# Altium schematic sheet Audio.SchDoc
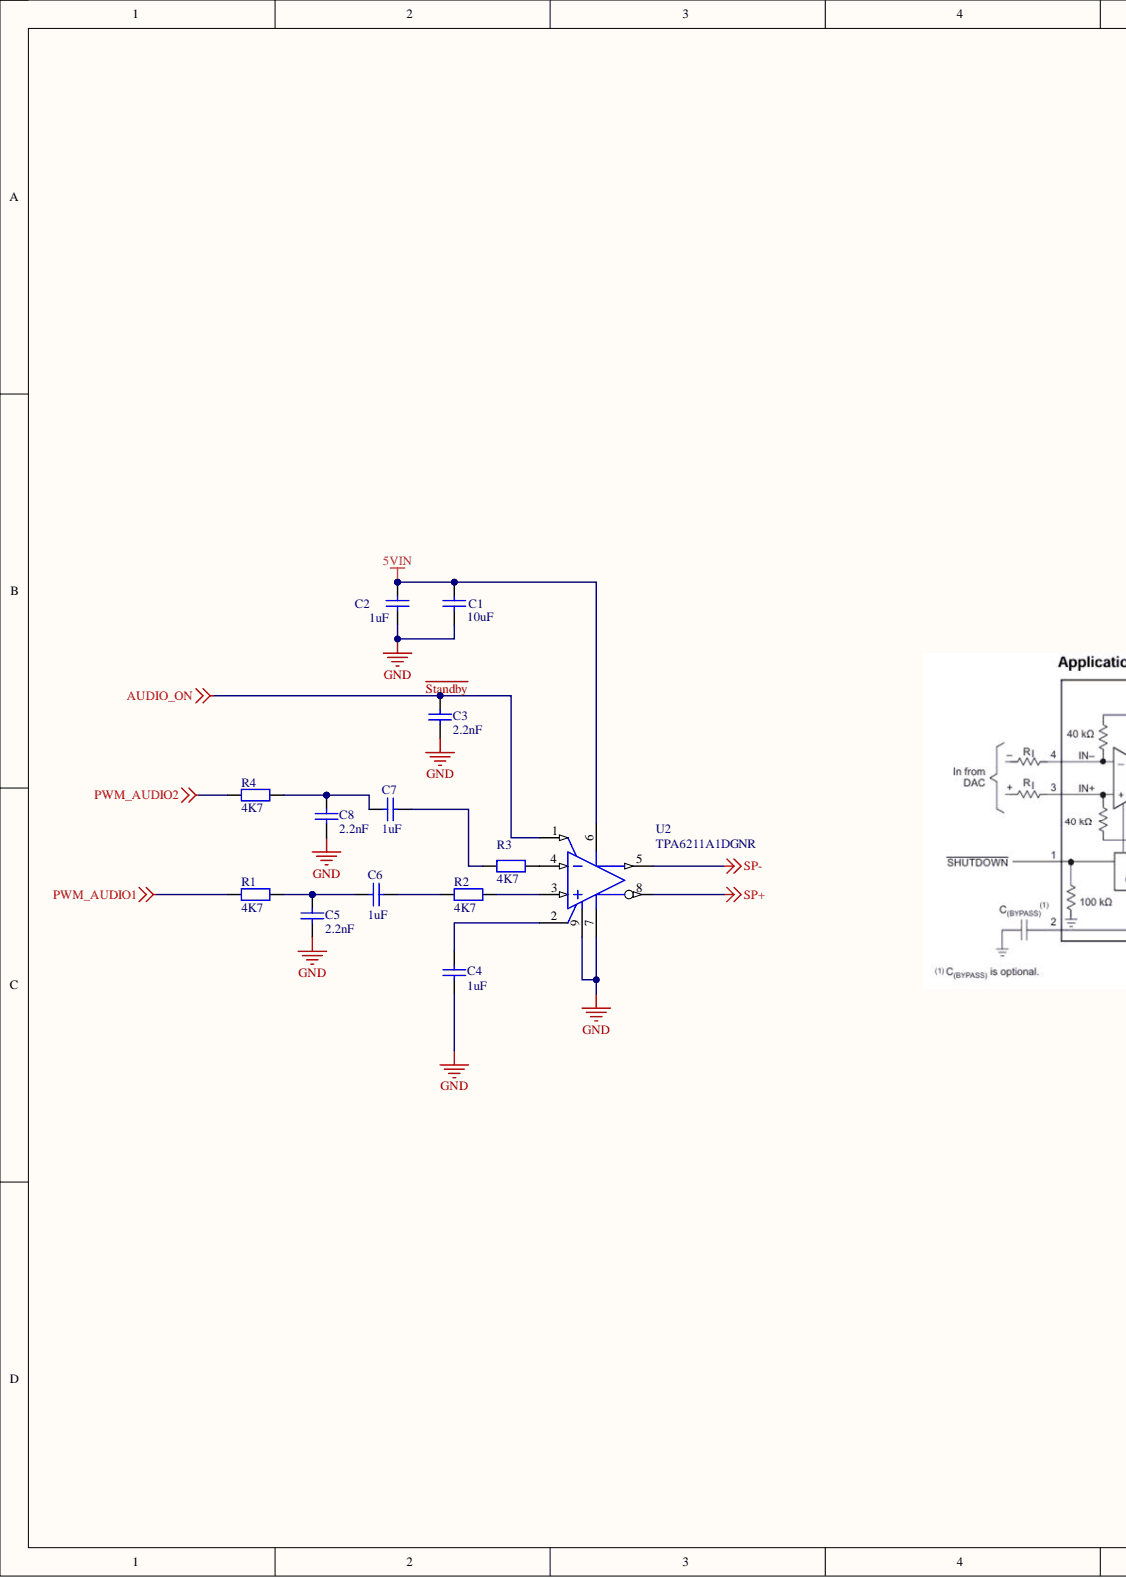
[diagram p0: Audio.SchDoc, left]
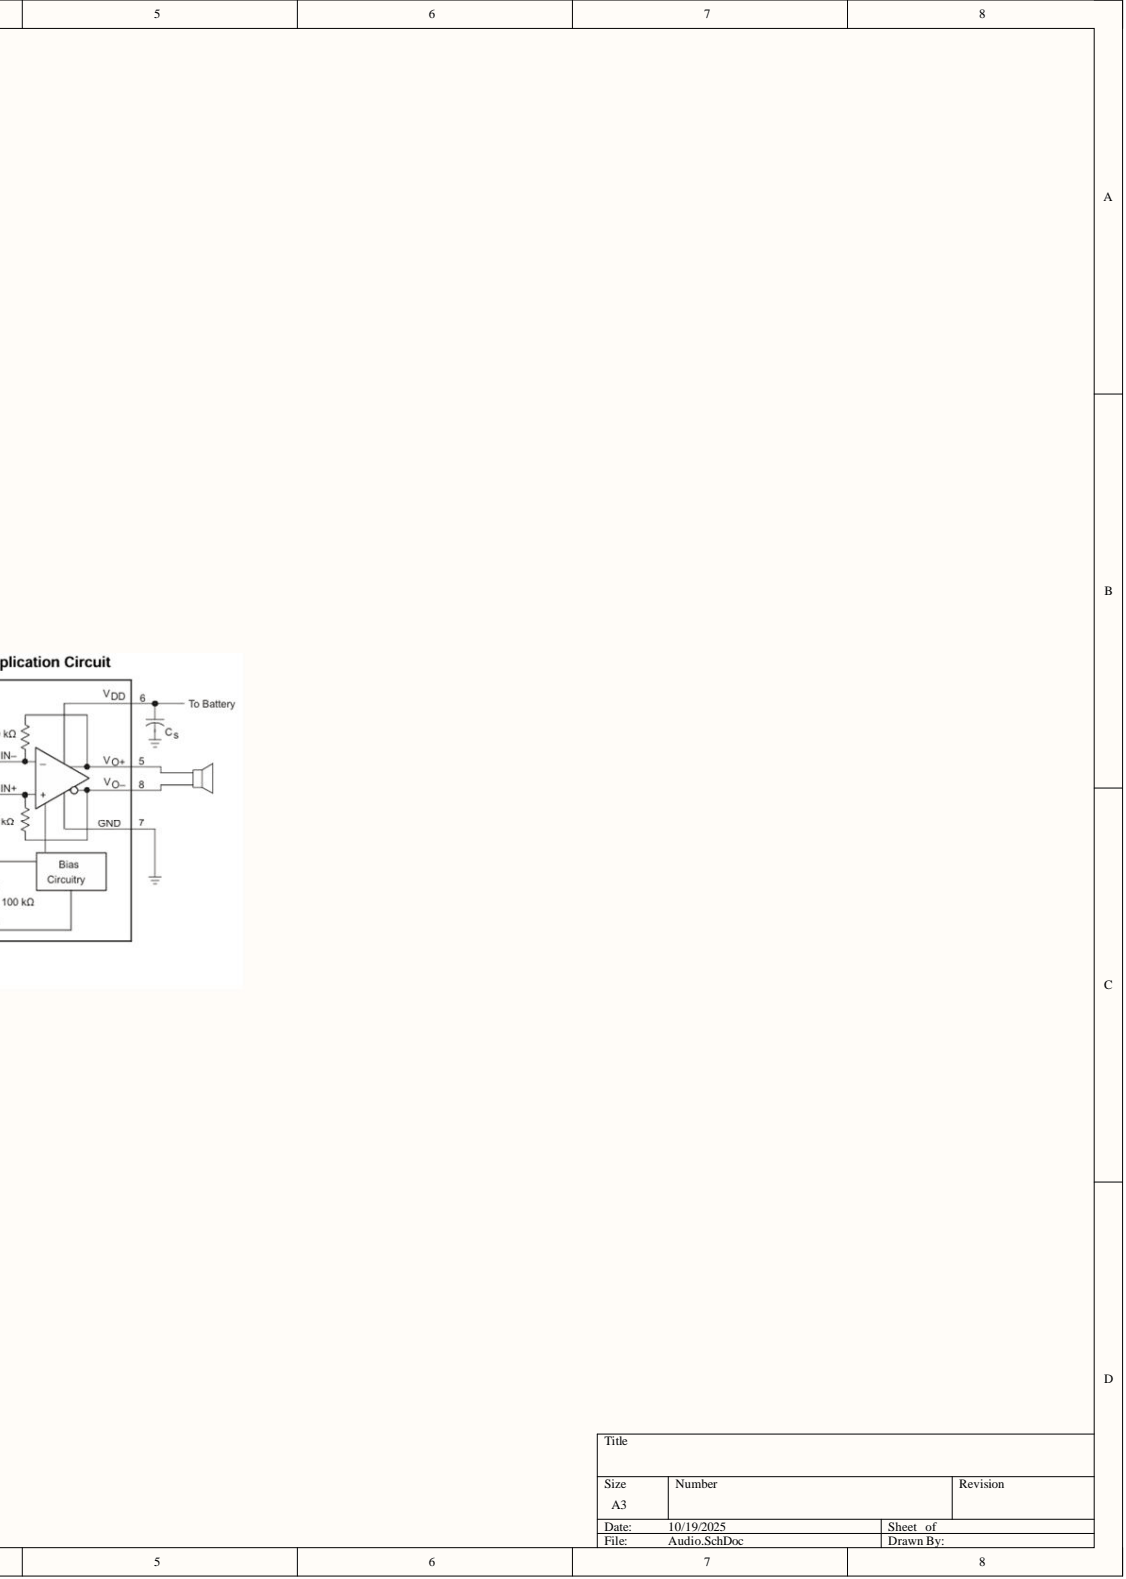
[diagram p1: Audio.SchDoc, right]

## Parts
C1  10uF  [PCB-2anwujj7xwxoywzjnffd-1]
C2  1uF  [PCB-fwsp7p2noy22sqednen5-1]
C3  2.2nF  [PCB-fwsp7p2noy22sqednen5-1]
C4  1uF  [PCB-fwsp7p2noy22sqednen5-1]
C5  2.2nF  [PCB-fwsp7p2noy22sqednen5-1]
C6  1uF  [PCB-fwsp7p2noy22sqednen5-1]
C7  1uF  [PCB-fwsp7p2noy22sqednen5-1]
C8  2.2nF  [PCB-fwsp7p2noy22sqednen5-1]
R1  4K7  [PCB-7wrvqjq7seaxrusqyahf-1]
R2  4K7  [PCB-7wrvqjq7seaxrusqyahf-1]
R3  4K7  [PCB-7wrvqjq7seaxrusqyahf-1]
R4  4K7  [PCB-7wrvqjq7seaxrusqyahf-1]
U2  TPA6211A1DGNR  [TI-DGN8-1760X1425TP_M]

## Nets
5VIN: C1.2 C2.2 U2.6
GND: C1.1 C2.1 C3.1 C4.1 C5.1 C8.1 U2.7 U2.9
PWM_AUDIO1: R1.1
PWM_AUDIO2: R4.1
SP+: U2.8
SP-: U2.5
~{Standby}: C3.2 U2.1
NetC4_2: C4.2 U2.2
NetC5_2: C5.2 C6.1 R1.2
NetC6_2: C6.2 R2.1
NetC7_1: C7.1 C8.2 R4.2
NetC7_2: C7.2 R3.1
NetR2_2: R2.2 U2.3
NetR3_2: R3.2 U2.4

## Library data of the parts
C1 = CMP-ccd6055105851311-1: CL32 Series 10 uF 25 V ±10 % Tolerance X7R SMT Multilayer Ceramic Capacitor; Manufacturer Part Number: CL32B106KAJNNNE; Capacitance: 10.0 µF; Capacitance Tolerance: ±10%; Lead-Free Status: Lead Free; Voltage Rating (DC): 25.0 V; Case/Package: 1210; Component Kind: Standard; Dielectric Characteristic: X7R; Library Name: My Personal Space; Mounting Style: Surface Mount; Octopart URL: http://octopart.com/cl32b106kajnnne-samsung-9301045; OctopartID: ccd6055105851311; RoHS: Compliant
C2-C8 = CMP-7557059-5: Cap Ceramic 1uF 50V X7R 10% SMD 0805 125C Plastic T/R; Manufacturer Part Number: CL21B105KBFNNNE; Capacitance: 1e-06 F; Lead-Free Status: Lead Free; Voltage Rating (DC): 50.0 V; Capacitance Tolerance: ±10%; Case/Package: 0805; Component Kind: Standard; Dielectric Characteristic: X7R; Library Name: My Personal Space; Mounting Style: Surface Mount; Octopart Url: https://octopart.com/cl21b105kbfnnne-samsung-11800304; OctopartID: e7c12e7fcc573806; RoHS: Compliant
R1-R4 = CMP-3bd8f394a633e41f-2: Res Thin Film 2.0 x 1.2 mm 2K Ohm 0.1% 0.1W(1/10W) 10ppm/ C Molded SMD Paper T/R; Manufacturer Part Number: RN73C2A2K0BTD; Case/Package (SI): 2012; ELV Compliant: Compliant; Power Rating: 100 mW; Resistance: 2.00 kΩ; Voltage Rating (DC): 100 V; Case/Package: 0805; Component Kind: Standard; Library Name: My Personal Space; Lifecycle Status: Active; Mounting Style: Surface Mount; Number of Pins: 2; Octopart URL: http://octopart.com/rn73c2a2k0btdf-te+connectivity-21411981; OctopartID: 3bd8f394a633e41f; Packaging: Tape & Reel (TR); REACH SVHC Compliance: No SVHC; Resistance Tolerance: ±0.1%; RoHS: Compliant
U2 = CMP-13603694-2: 3.1-W Mono, Fully Differential, Class-AB Audio Amplifier, -40 to 85 degC, 8-pin SOP (DGN8), Green (RoHS & no Sb/Br); Lead-Free Status: Lead Free; Number of Channels: 1; Output Power: 3.1 W; Supply Voltage (DC): 5.5 V; Case/Package: HTSSOP; Component Kind: Standard; Library Name: My Personal Space; Lifecycle Status: Active; Manufacturer Part Number: TPA6211A1DGNR; Number of Pins: 8; Octopart Url: https://octopart.com/tpa6211a1dgnr-texas+instruments-2463557; OctopartID: 5c8d95a2eeae834a; Packaging: Tape & Reel (TR); RoHS: Compliant
